# Altium schematic sheet LED_Roulette_Wheel.SchDoc(LED_Roulette_Wheel)
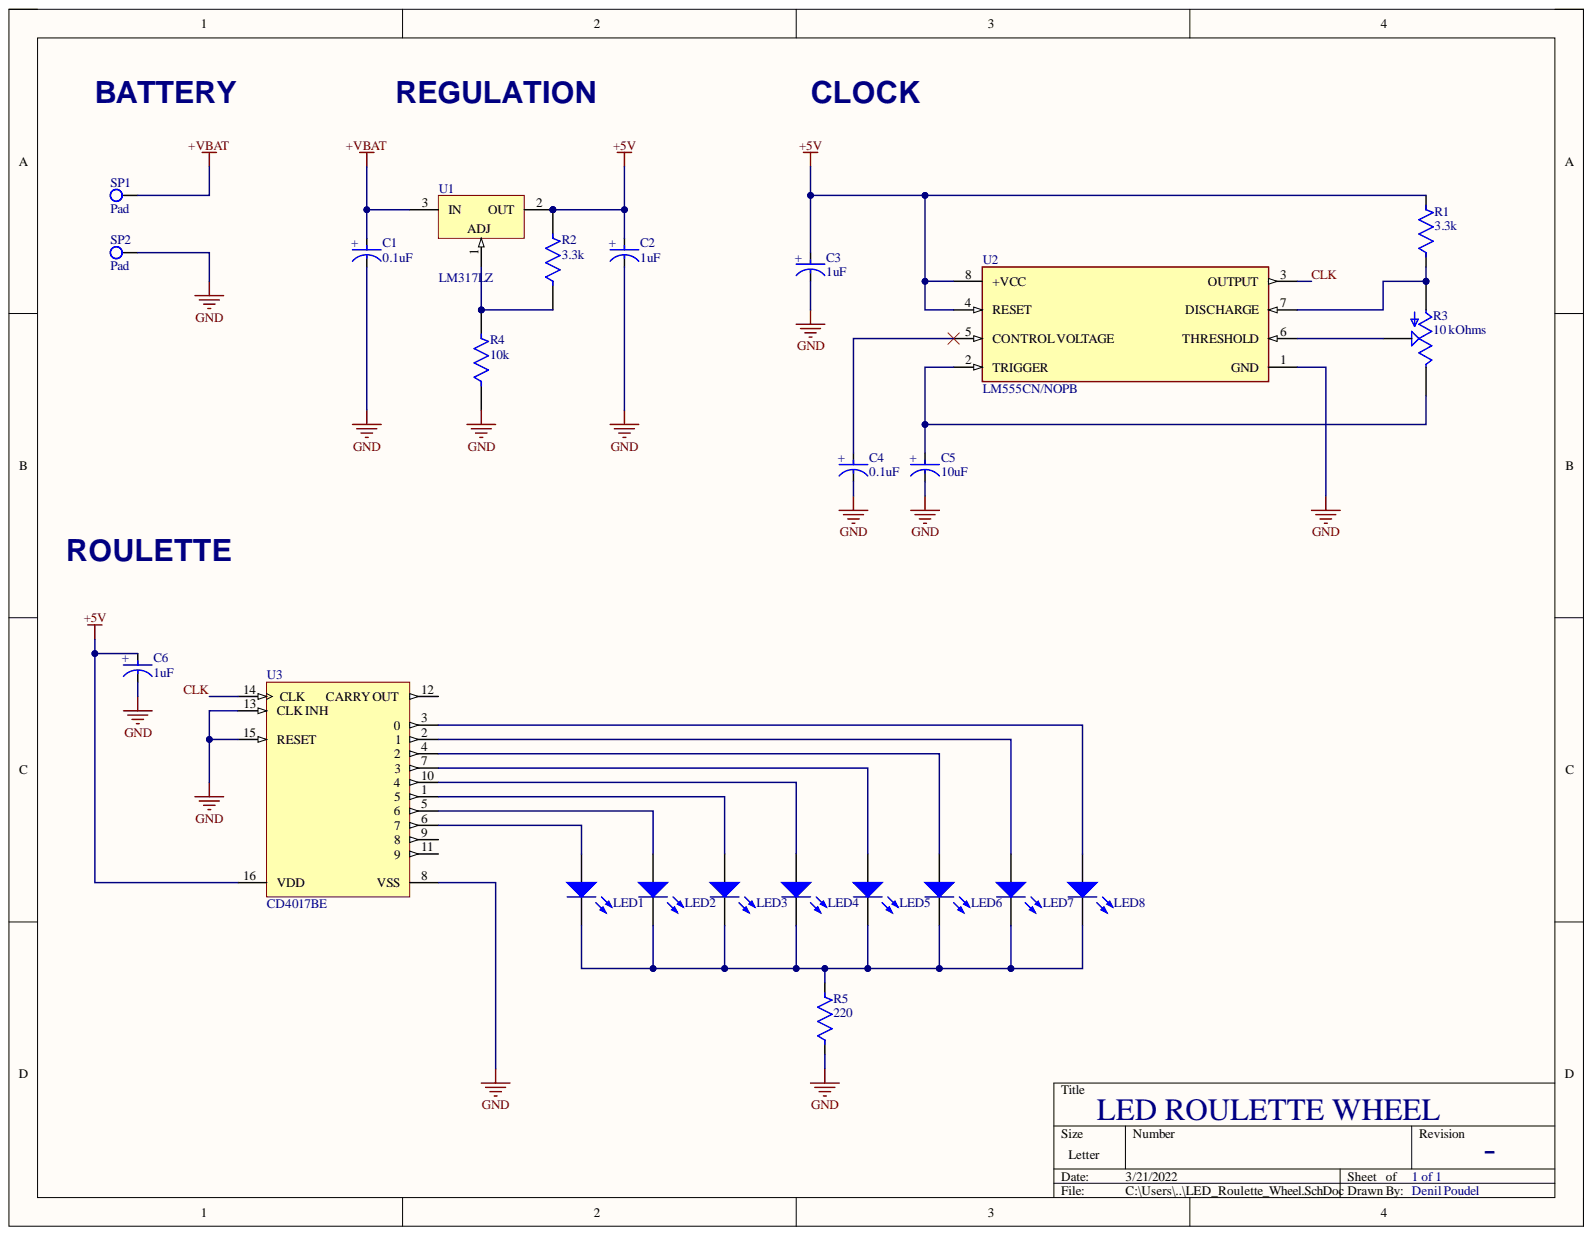
[diagram p0: LED_Roulette_Wheel.SchDoc]

## Parts
C1  0.1uF  [FP-UPW1E220MDD-MFG]
C2  1uF  [FP-UPW1E220MDD-MFG]
C3  1uF  [FP-UPW1E220MDD-MFG]
C4  0.1uF  [FP-UPW1E220MDD-MFG]
C5  10uF  [FP-UPW1E220MDD-MFG]
C6  1uF  [FP-UPW1E220MDD-MFG]
LED1  151051VS04000  [Type 5mm]  (value not drawn)
LED2  151051VS04000  [Type 5mm]  (value not drawn)
LED3  151051VS04000  [Type 5mm]  (value not drawn)
LED4  151051VS04000  [Type 5mm]  (value not drawn)
LED5  151051VS04000  [Type 5mm]  (value not drawn)
LED6  151051VS04000  [Type 5mm]  (value not drawn)
LED7  151051VS04000  [Type 5mm]  (value not drawn)
LED8  151051VS04000  [Type 5mm]  (value not drawn)
R1  3.3k  [FP-MFR-25-MFG]
R2  3.3k  [FP-MFR-25-MFG]
R3  10 kOhms  [TRIM_3362P-1-104TLF]
R4  10k  [FP-MFR-25-MFG]
R5  220  [FP-MFR-25-MFG]
SP1  Pad  [SP]
SP2  Pad  [SP]
U1  LM317LZ  [Z03A]
U2  LM555CN/NOPB  [FP-P0008A-MFG]
U3  CD4017BE  [N0016A]

## Nets
+5V: C2.2 C3.2 C6.2 R1.2 R2.2 U1.2 U2.4(RESET) U2.8(+VCC) U3.16(VDD)
+VBAT: C1.2 SP1.1 U1.3
GND: C1.1 C2.1 C3.1 C4.1 C5.1 C6.1 R4.1 R5.1 SP2.1 U2.1(GND) U3.8(VSS) U3.13(CLK_INH) U3.15(RESET)
CLK: U2.3(OUTPUT) U3.14(CLK)
NetC4_2: C4.2 U2.5(CONTROL_VOLTAGE)
NetC5_2: C5.2 R3.3 U2.2(TRIGGER)
NetLED1_1: LED1.1 LED2.1 LED3.1 LED4.1 LED5.1 LED6.1 LED7.1 LED8.1 R5.2
NetLED1_2: LED1.2 U3.6
NetLED2_2: LED2.2 U3.5
NetLED3_2: LED3.2 U3.1
NetLED4_2: LED4.2 U3.10
NetLED5_2: LED5.2 U3.7
NetLED6_2: LED6.2 U3.4
NetLED7_2: LED7.2 U3.2
NetLED8_2: LED8.2 U3.3
NetR1_1: R1.1 R3.1 U2.7(DISCHARGE)
NetR2_1: R2.1 R4.2 U1.1
NetR3_2: R3.2 U2.6(THRESHOLD)

Not connected: U3 9 11 12(CARRY_OUT)

## Library data of the parts
C1-C6 = CMP-12462-000010-1: 22uF 25 V dc Aluminium Electrolytic Capacitor, PW Series 2000h 5 (Dia.) x 11mm; Manufacturer: Nichicon; Manufacturer Part Number: UPW1E220MDD; Automotive Grade: Grade 2; Dissipation Factor: 14%; Leakage Current: 16.5uA; Life: 2000Hours; Material: Aluminum; Ripple Current: 180mA; Tolerance: 20%; Voltage Rating: 25V; Automotive: Yes; Capacitance: 22uF; Category: Cap; Component Kind: Standard; ComponentLink2Description: Datasheet; ComponentLink2URL: https://products.nichicon.co.jp/en/pdf/XJA043/e-upw.pdf; Device Class L1: Passive Components; Device Class L2: Capacitors; Device Class L3: Aluminum Electrolytic Capacitors; Digikey Description: CAP ALUM 22UF 20% 25V RADIAL; Height: 12.5mm; Lead Free: Yes; Library Name: Altium Content Vault; Octopart: https://octopart.com/upw1e220mdd-nichicon-202818; Package: 0; RoHS: TRUE; Temperature: -55°C to +105°C; Temperature Range High: +105°C; Temperature Range Low: -55°C; Value: 22uF
LED1-LED8 = CMP-1488-00007-1: THT LED round mono-color color lens, WL-TMRW, Bright Green; Manufacturer: Wuerth Elektronik; Component Kind: Standard; ComponentLink1Description: Manufacturer URL; ComponentLink1URL: http://www.we-online.com; ComponentLink2Description: Datasheet; ComponentLink2URL: http://katalog.we-online.de/pbs/datasheet/7448042001.pdfhttp://katalog.we-online.de/pbs/datasheet/7448042001.pdfhttp://katalog.we-online.de/pbs/datasheet/7448042001.pdfhttp://katalog.we-online.de/pbs/datasheet/151051VS04000.pdf; Emitting color: Bright Green; Library Name: Altium Content Vault; PackageReference: Type 5mm; PartNumber: 151051VS04000
R1 R2 R4 R5 = CMP-03413-000001-1: 1.18 kOhms ±1% 0.25W, 1/4W Through Hole Resistor Axial  Metal Film; Manufacturer: Yageo; Manufacturer Part Number: MFR-25FBF52-1K18; Resistance: 1.18kΩ; Temperature Coefficient: 100ppm/°C; Value: 1.18kΩ; Voltage Rating: 250V; Automotive: No; Category: Res; Component Kind: Standard; ComponentLink2Description: Datasheet; ComponentLink2URL: https://www.yageo.com/upload/media/product/products/datasheet/lr/Yageo_LR_MFR_1.pdf; Composition: Metal Film; Device Class L1: Passive Components; Device Class L2: Resistors; Device Class L3: Through-Hole Resistors; Digikey Description: RES 1.18K OHM 1/4W 1% AXIAL; Height: 2.6mm; Ipc Land Pattern Name: RESAD1000W75L680D260; Lead Free: Yes; Library Name: Altium Content Vault; Mouser Description: Metal Film Resistors 1.18K OHM 1/4W 1%; Octopart: https://octopart.com/mfr-25fbf52-1k18-yageo-56251681; Package: MFR-25; Power Rating: 0.25W; RoHS: TRUE; Series: MFR; Temperature: -55°C to +155°C; Temperature Range High: +155°C; Temperature Range Low: -55°C; Tolerance: 1%
R3 = 3362P-1-104TLF: 10 kOhms 0.5W, 1/2W PC Pins Through Hole Trimmer Potentiometer Cermet 1 Turn Top Finger Adjustment; MF: Bourns; Availability: Warning; Check_prices: https://www.snapeda.com/parts/3362P-1-104TLF/Bourns/view-part/1448275/?ref=eda; Component Kind: Standard; MP: 3362P-1-104TLF; Package: 3362P-1-T Bourns Inc.; Price: None; Purchase-URL: https://pricing.snapeda.com/search/part/3362P-1-104TLF/?ref=eda; SnapEDA_Link: https://www.snapeda.com/parts/3362P-1-104TLF/Bourns/view-part/1448275/?ref=snap; Library Name: LED_Roulette_Wheel.SchLib
SP1 SP2 = SP: Component Kind: Standard; Library Name: LED_Roulette_Wheel.SchLib
U1 = CMP-0062-00072-2: 3-Terminal Adjustable Regulator, 3-pin TO-92; Manufacturer: National Semiconductor; CompType: LDO IC; PackageTopMarking: NSXYTT*LM317*LZ; PowerWise: N; Code_JEDEC: TO-226-AA; Component Kind: Standard; ComponentLink1Description: LM317L Datasheet; ComponentLink1URL: http://www.national.com/ds/LM/LM317L.pdf; ComponentLink2Description: LM317L Web Page; ComponentLink2URL: http://www.national.com/pf/LM/LM317L.html; ComponentLink3Description: Z03A Package Page; ComponentLink3URL: http://www.national.com/packaging/folders/z03a.html; DatasheetVersion: Apr-2008; Library Name: Altium Content Vault; PackageDescription: TO-92, Molded, 3 Lead; PackageReference: Z03A; PackageVersion: revG, Sep-2000; PinCount: 3; RevisionNote: Component URLs added; RoHS: N
U2 = CMP-0056-00016-4: IC OSC SINGLE TIMER 100KHZ 8-DIP; Manufacturer: Texas Instruments; Max Junction Temp: +70°C; Max Supply Voltage: 16V; Min Supply Voltage: 4.5V; Nominal Supply Current: 3mA; Number Of Timers/Counters: 1; Standoff Height: 0.51mm; Ambient Temperature Range High: +70°C; Ambient Temperature Range Low: 0°C; Automotive: No; Category: IC; Component Kind: Standard; ComponentLink2Description: Datasheet; ComponentLink2URL: http://www.ti.com/lit/ds/symlink/lm555.pdf; Device Class L1: Integrated Circuits (ICs); Device Class L2: Clock and Timing; Device Class L3: Timers and Oscillators; Digikey Description: IC OSC SINGLE TIMER 100KHZ 8-DIP; Height: 5.08mm; Lead Free: Yes; Library Name: Altium Content Vault; Manufacturer Part Number: LM555CN/NOPB; Octopart: https://octopart.com/lm555cn%2Fnopb-texas+instruments-24814897; Package: P0008A; RoHS: TRUE; Temperature: 0°C to +70°C; Temperature Range High: +70°C; Temperature Range Low: 0°C
U3 = CMP-1623-00541-2: CMOS Decade Counter with 10 Decoded Outputs, N0016A, TUBE; Manufacturer: Texas Instruments; Bits(#): 10; F @ Nom Voltage(Max)(Mhz): 8; ICC @ Nom Voltage(Max)(mA): 0.03; Inputs: 1; Output Drive (IOL/IOH)(Max)(mA): 1.5/-1.5; Rating: Military; Schmitt Trigger: No; Technology Family: CD4000; tpd @ Nom Voltage(Max)(ns): 270; VCC(Max)(V): 18; VCC(Min)(V): 3; Code_JEDEC: MS-001; Component Kind: Standard; DatasheetVersion: SCHS027C; Function: Counter; Generic Part Number: CD4017B; Input Type: CMOS; Library Name: Altium Content Vault; Operating Temperature Range(C): -55 to 125; Output Type: CMOS; PackageReference: N0016A; Type: Decade; Voltage(Nom)(V): 10
